# Altium schematic sheet WatchDog.SchDoc
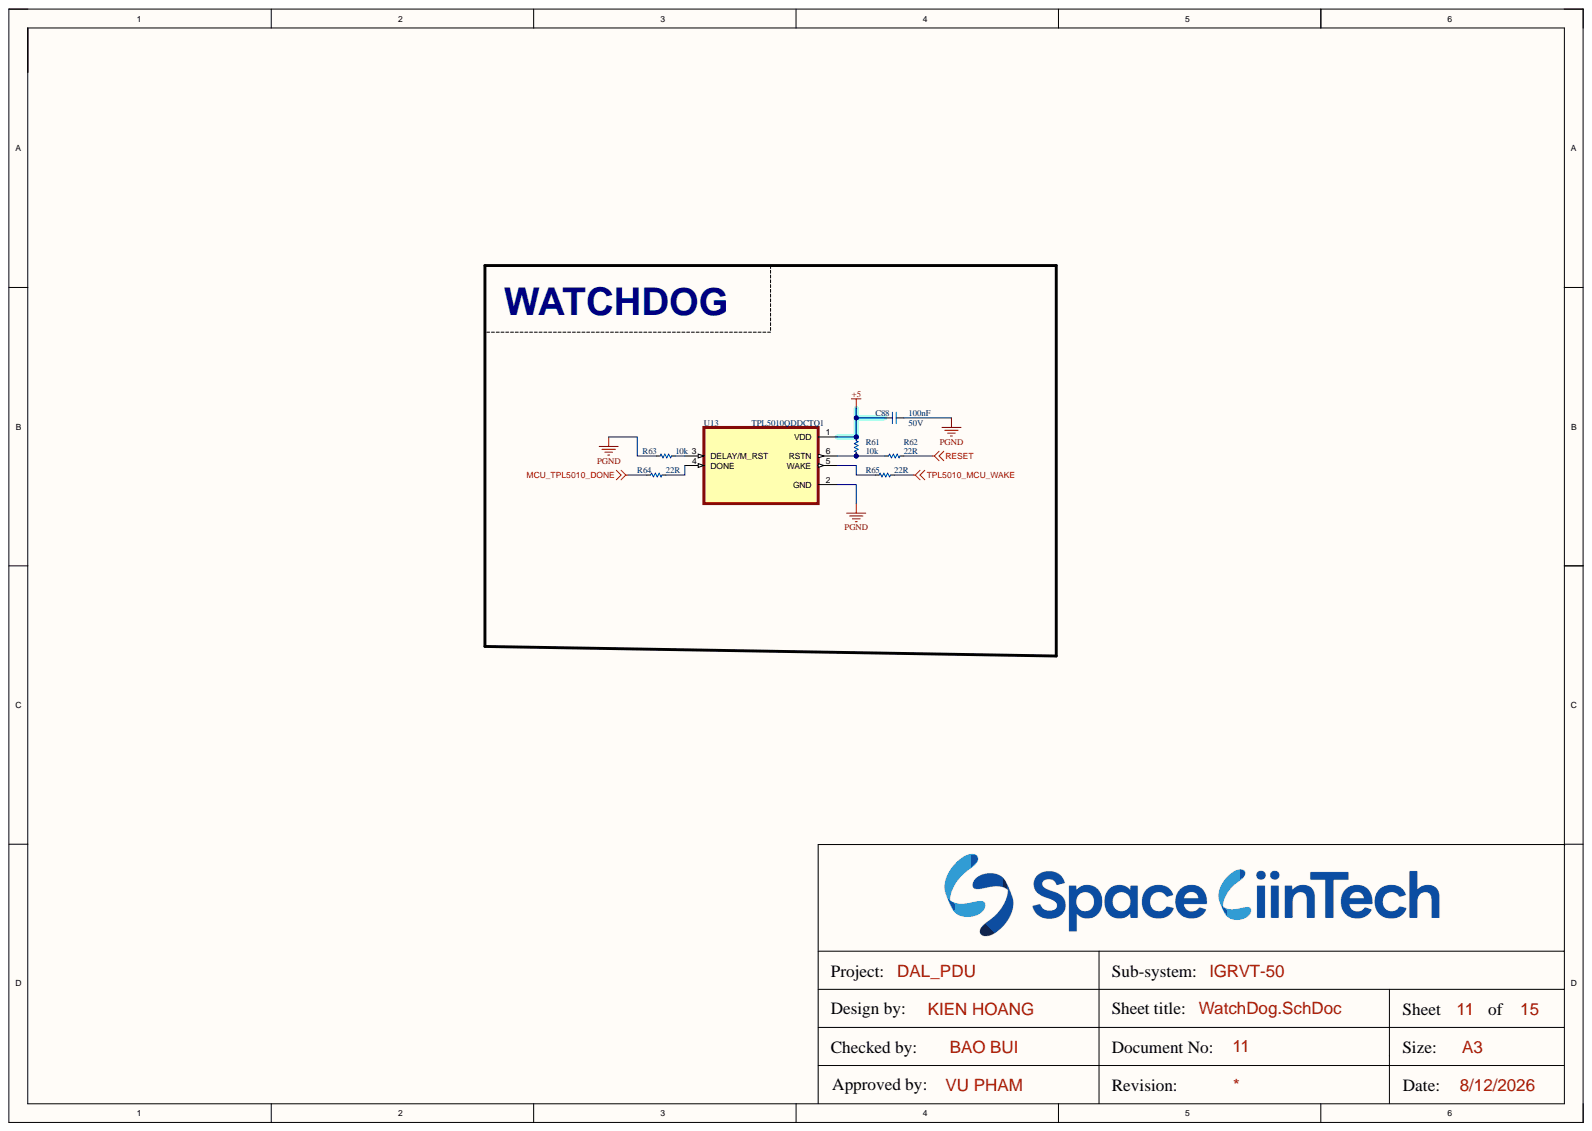
[diagram p0: WatchDog.SchDoc]

## Parts
C88  100nF  Voltage: 50V  [CAP_C_1608X80]
R61  10k  [RES_C_1608X60]
R62  22R  [RES_C_1608X60]
R63  10k  [RES_C_1608X60]
R64  22R  [RES_C_1608X60]
R65  22R  [RES_C_1608X60]
U13  TPL5010QDDCTQ1  [SOT-23-THN-6]

## Nets
+5: C88.2 R61.2 U13.1(VDD)
MCU_TPL5010_DONE: R64.2
PGND: C88.1 R63.1 U13.2(GND)
RESET: R62.1
TPL5010_MCU_WAKE: R65.1
NetR61_1: R61.1 R62.2 U13.6(RSTN)
NetR63_2: R63.2 U13.3(DELAY/M_RST)
NetR64_1: R64.1 U13.4(DONE)
NetR65_2: R65.2 U13.5(WAKE)

## Library data of the parts
C88 = _CAP_C_2: CAP; Component Kind: Standard; Library Name: LABLIB.SchLib
R61-R65 = _RES_B_2_2: RES; Component Kind: Standard; Library Name: LABLIB.SchLib
U13 = TPL5010QDDCTQ1: Component Kind: Standard; Library Name: KIENASIY123_LIB.IntLib
